# Altium schematic sheet Sheet2.SchDoc
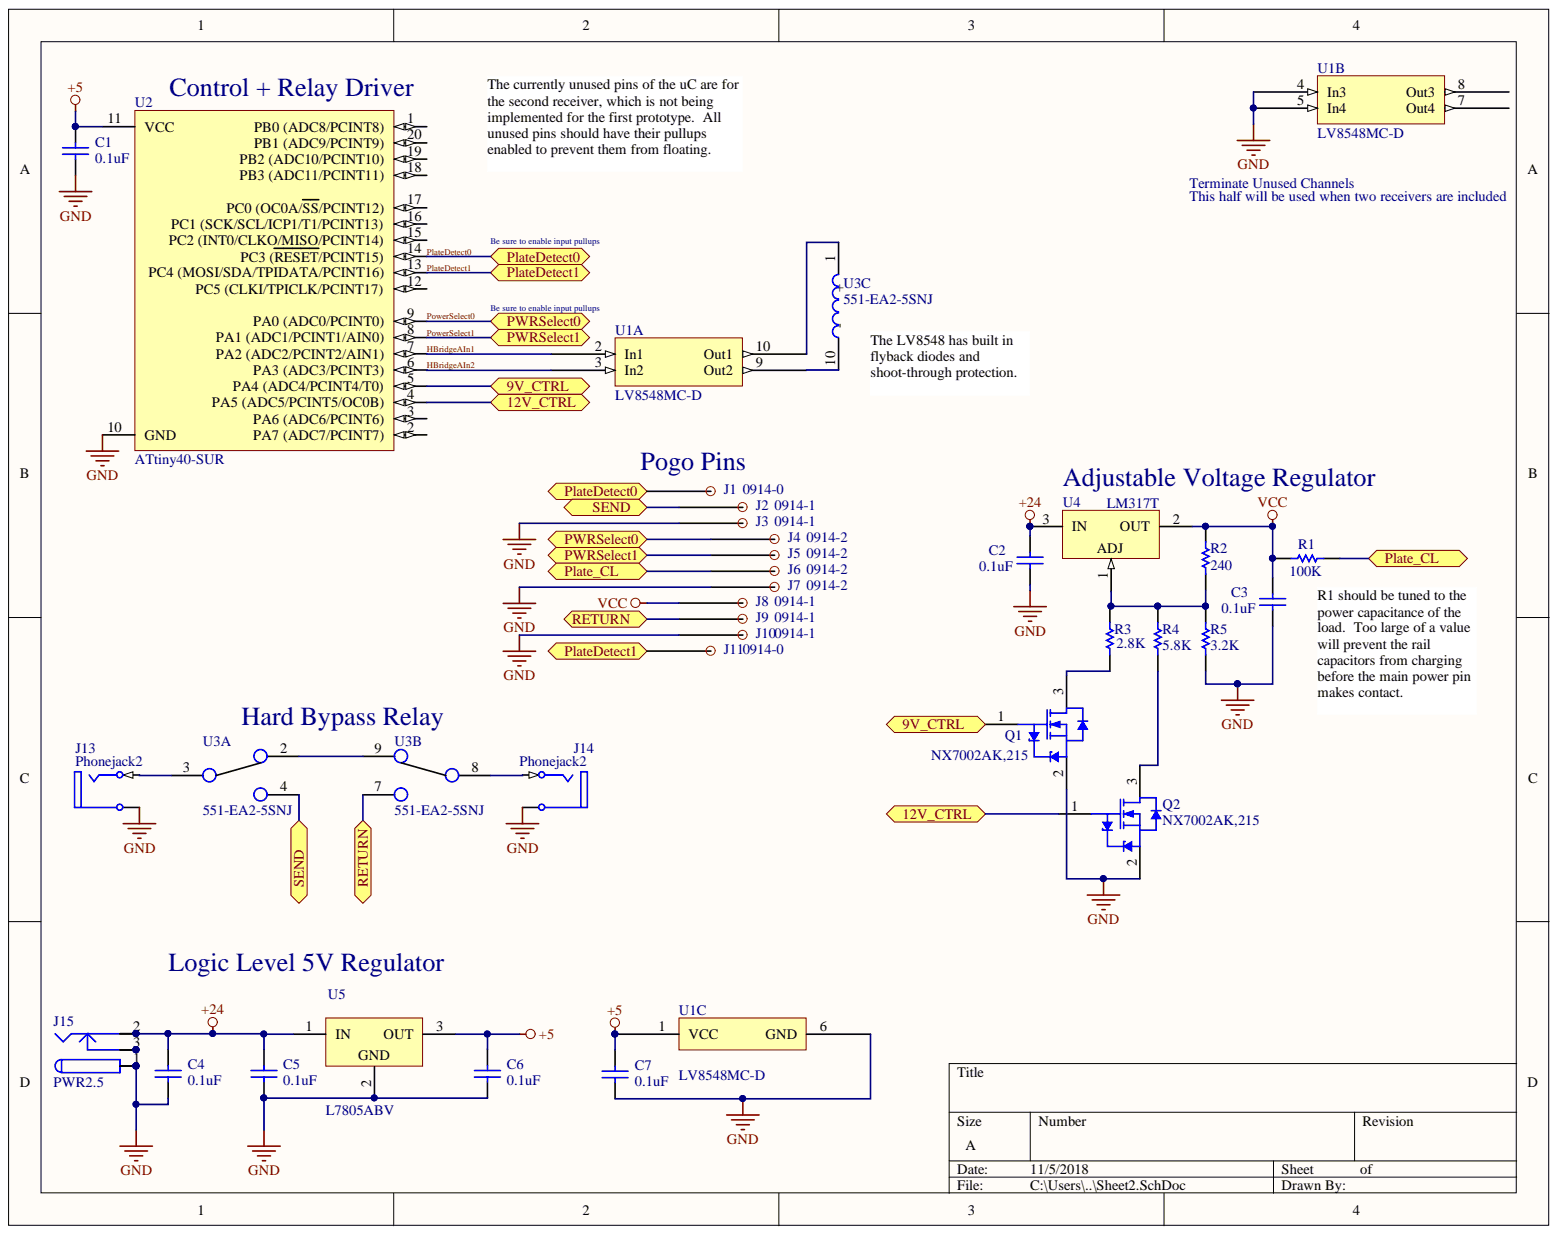
[diagram p0: Sheet2.SchDoc]

## Parts
C1  value 0.1uF  [RAD-0.3]  (hidden comment: Cap)
C2  value 0.1uF  [RAD-0.3]  (hidden comment: Cap)
C3  value 0.1uF  [RAD-0.3]  (hidden comment: Cap)
C4  value 0.1uF  [RAD-0.3]  (hidden comment: Cap)
C5  value 0.1uF  [RAD-0.3]  (hidden comment: Cap)
C6  value 0.1uF  [RAD-0.3]  (hidden comment: Cap)
C7  value 0.1uF  [RAD-0.3]  (hidden comment: Cap)
J1  0914-0-15-20-77-14-11-0  [MillMax0_025]  (value not drawn)
J2  0914-1-15-20-77-14-11-0  [MillMax0_025]  (value not drawn)
J3  0914-1-15-20-77-14-11-0  [MillMax0_025]  (value not drawn)
J4  0914-2-15-20-77-14-11-0  [MillMax0_025]  (value not drawn)
J5  0914-2-15-20-77-14-11-0  [MillMax0_025]  (value not drawn)
J6  0914-2-15-20-77-14-11-0  [MillMax0_025]  (value not drawn)
J7  0914-2-15-20-77-14-11-0  [MillMax0_025]  (value not drawn)
J8  0914-1-15-20-77-14-11-0  [MillMax0_025]  (value not drawn)
J9  0914-1-15-20-77-14-11-0  [MillMax0_025]  (value not drawn)
J10  0914-1-15-20-77-14-11-0  [MillMax0_025]  (value not drawn)
J11  0914-0-15-20-77-14-11-0  [MillMax0_025]  (value not drawn)
J13  Phonejack2  [JACK/6-V2]
J14  Phonejack2  [JACK/6-V2]
J15  PWR2.5  [KLD-0202]
Q1  NX7002AK,215  [NXP-SOT23_N]
Q2  NX7002AK,215  [NXP-SOT23_N]
R1  value 100K  [AXIAL-0.3]  (hidden comment: Res1)
R2  value 240  [AXIAL-0.3]  (hidden comment: Res1)
R3  value 2.8K  [AXIAL-0.3]  (hidden comment: Res1)
R4  value 5.8K  [AXIAL-0.3]  (hidden comment: Res1)
R5  value 3.2K  [AXIAL-0.3]  (hidden comment: Res1)
U1  LV8548MC-D  [SOP10]  (parts A,B,C)
U2  ATtiny40-SUR  [20S2_N]
U3  EA2-5SNJ  Supplier Part Number 1: 551-EA2-5SNJ  (parts A,B,C)
U4  LM317T  [TO-220]
U5  L7805ABV  [TO220]

## Ports
9V_CTRL, 12V_CTRL, Plate_CL, PlateDetect0, PlateDetect1, PWRSelect0, PWRSelect1, RETURN, SEND

## Nets
+5: C1.2 C6.2 C7.2 U1.1(VCC) U2.11(VCC) U5.3
+24: C2.2 C4.2 C5.2 J15.2 J15.2A J15.2B U4.3 U5.1
GND: C1.1 C2.1 C3.1 C4.1 C5.1 C6.1 C7.1 J3.1 J7.1 J10.1 J13.5 J14.5 J15.1 J15.1A J15.1B Q1.2 Q2.2 R5.1 U1.4(In3) U1.5(In4) U1.6(GND) U2.10(GND) U5.2
VCC: C3.2 J8.1 R1.2 R2.2 U4.2
9V_CTRL: Q1.1 U2.5(PA4_(ADC4/PCINT4/T0)) ; port 9V_CTRL
12V_CTRL: Q2.1 U2.4(PA5_(ADC5/PCINT5/OC0B)) ; port 12V_CTRL
HBridgeAIn1: U1.2(In1) U2.7(PA2_(ADC2/PCINT2/AIN1))
HBridgeAIn2: U1.3(In2) U2.6(PA3_(ADC3/PCINT3))
Plate_CL: J6.1 R1.1 ; port Plate_CL
PlateDetect0: J1.1 U2.14(PC3_(RESET/PCINT15)) ; port PlateDetect0
PlateDetect1: J11.1 U2.13(PC4_(MOSI/SDA/TPIDATA/PCINT16)) ; port PlateDetect1
PowerSelect0: J4.1 U2.9(PA0_(ADC0/PCINT0)) ; port PWRSelect0
PowerSelect1: J5.1 U2.8(PA1_(ADC1/PCINT1/AIN0)) ; port PWRSelect1
RETURN: J9.1 U3.7 ; port RETURN
SEND: J2.1 U3.4 ; port SEND
NetJ13_1: J13.1 U3.3
NetJ14_1: J14.1 U3.8
NetJ15_3: J15.3 J15.3A J15.3B
NetQ1_3: Q1.3 R3.1
NetQ2_3: Q2.3 R4.1
NetR2_1: R2.1 R3.2 R4.2 R5.2 U4.1
NetU1_9: U1.9(Out2) U3.10(-)
NetU1_10: U1.10(Out1) U3.1(+)
NetU3_2: U3.2 U3.9

Not connected: U1 7(Out4) 8(Out3); U2 1(PB0_(ADC8/PCINT8)) 2(PA7_(ADC7/PCINT7)) 3(PA6_(ADC6/PCINT6)) 12(PC5_(CLKI/TPICLK/PCINT17)) 15(PC2_(INT0/CLKO/MISO/PCINT14)) 16(PC1_(SCK/SCL/ICP1/T1/PCINT13)) 17(PC0_(OC0A/SS/PCINT12)) 18(PB3_(ADC11/PCINT11)) 19(PB2_(ADC10/PCINT10)) 20(PB1_(ADC9/PCINT9))

## Library data of the parts
C1-C7 = Cap: Capacitor; Component Kind: Standard; LatestRevisionDate: 17-Jul-2002; LatestRevisionNote: Re-released for DXP Platform.; Library Name: Miscellaneous Devices.IntLib; PackageDescription: Radial Cap, Thru-Hole; 2 Leads; 0.3 in Pin Spacing; PackageReference: RAD-0.3; Published: 8-Jun-2000; Signal Integrity: Cap; Simulation: CAP; Publisher: Altium Limited
J1 J11 = 0914-0-15-20-77-14-11-0: CONN PIN SPRING-LOADED PCB GOLD; Manufacturer: Mill-Max; Body Material: Brass; Current Rating: 2A; Number of Contacts: 2; P*: 0914-0; Resistance: 20mR; Component Kind: Standard; ComponentLink1Description: http://www.mouser.com/ds/2/273/025-259718.pdf; ComponentLink1URL: http://www.mouser.com/ds/2/273/025-259718.pdf; ComponentLink2Description: http://www.farnell.com/datasheets/1474874.pdf; ComponentLink2URL: http://www.farnell.com/datasheets/1474874.pdf; ComponentLink3Description: https://www.alliedelec.com/m/d/29d0a7827c1825043739d39c5225e64e.pdf; ComponentLink3URL: https://www.alliedelec.com/m/d/29d0a7827c1825043739d39c5225e64e.pdf; Contact Material: Copper Alloy; Contact Plating: Gold; Lead Free: Lead Free; Length: 0.302inch; Manufacturer Part Number: 0914-0-15-20-77-14-11-0; Material: Copper Alloy; Mount: Panel,Through Hole; Number of Rows: 1; Package Quantity: 500; Packaging: Bulk; Plating: Gold; Pricing 1: 1=0.75, 10=0.706, 25=0.6352, 50=0.5648, 100=0.5412, 250=0.49412, 500=0.4706, 1000=0.38825, 2500=0.35295 (USD); REACH SVHC: Unknown; Stock 1: 29402; Supplier 1: Digi-Key; Termination: Solder; Library Name: NickPhamParts.IntLib
J2 J3 J8-J10 = 0914-1-15-20-77-14-11-0: CONNECTOR; Manufacturer: Mill-Max; J*: 0914-1; Plating: Gold; Component Kind: Standard; Manufacturer Part Number: 0914-1-15-20-77-14-11-0; Material: Brass; Mount: Through Hole; Pricing 1: 1=0.77, 10=0.673, 25=0.6336, 50=0.6072, 100=0.5808, 250=0.528, 500=0.4752, 1000=0.4224, 2500=0.3828 (USD); Stock 1: 17746; Supplier 1: Digi-Key; Library Name: NickPhamParts.IntLib
J4-J7 = 0914-2-15-20-77-14-11-0: CONN PIN PCB .362"; Manufacturer: Mill-Max; Plating: Gold; Component Kind: Standard; Manufacturer Part Number: 0914-2-15-20-77-14-11-0; Material: Brass; Mount: Through Hole; Pricing 1: 1=0.81, 10=0.71, 25=0.6688, 50=0.6408, 100=0.6129, 250=0.5572, 500=0.50148, 1000=0.44576, 2500=0.40397 (USD); Stock 1: 7703; Supplier 1: Digi-Key; Supplier Part Number 1: 0914-2; Library Name: NickPhamParts.IntLib
J13 J14 = Phonejack2: Jack Socket, 1/4" [6.5mm], Thru-Hole, Vertical, 2-Conductor Open Circuit; DrillAndSlotSize: Corresponds to nominal value.; PackageDocument: 2003; PCB_Layout: Complies with manufacturer's recommendation.; Component Kind: Standard; ComponentLink1Description: Manufacturer Link; ComponentLink1URL: http://www.cliffuk.co.uk/; DatasheetDocument: 2003; LatestRevisionDate: 15-Jul-2004; LatestRevisionNote: Component Replaced.; Library Name: Miscellaneous Connectors.IntLib; PackageReference: S1VS_SNS; Signal Integrity: JACK Socket; Publisher: Altium Limited
J15 = PWR2.5: Low Voltage Power Supply Connector; Component Kind: Standard; ComponentLink1Description: Manufacturer Link; ComponentLink1URL: http://domino.kycon.com/internet/Products.html; DatasheetDocument: 09-May-2002; Library Name: Miscellaneous Connectors.IntLib; Published: 17-Dec-2003; Signal Integrity: Connector; Publisher: Altium Limited
Q1 Q2 = NX7002AK,215: Single N-Channel Trench MOSFET, 60 V, -55 to 150 degC, 3-Pin SOT23, Tape and Reel; Manufacturer: NXP Semiconductors; Channel Type: N; Ciss [typ] (pF): 11; Coss [typ] (pF): 3.4; Packing: Tape & Reel; VDS [max] (V): 60; VGSth [typ] (V): 1.6; Code_JEDEC: TO-236AB; Component Kind: Standard; ComponentLink1Description: Manufacturer URL; ComponentLink1URL: http://www.nxp.com/; ComponentLink2Description: Datasheet; ComponentLink2URL: http://www.nxp.com/documents/data_sheet/NX7002AK.pdf; ComponentLink3Description: Package Specification; ComponentLink3URL: http://www.nxp.com/documents/outline_drawing/sot023_po.pdf; DatasheetVersion: Rev. 5; Library Name: NXP Semiconductors Standard MOSFETs.IntLib; Mounting Technology: Surface Mount; PackageDescription: 3-Pin Small Outline Transistor Package, Body 2.9 x 1.3 mm, Pitch 0.95 mm; PackageReference: SOT23; PackageVersion: 06-03-16, 03/2006; PartNumber: NX7002AK,215; Supplier 1: Digi-Key; Supplier 2: Digi-Key; Supplier 3: Digi-Key; Supplier 4: Mouser; Supplier Part Number 1: 568-10510-2-ND; Supplier Part Number 2: 568-10510-1-ND; Supplier Part Number 3: 568-10510-6-ND; Supplier Part Number 4: 771-NX7002AK,215
R1-R5 = Res1: Resistor; Component Kind: Standard; LatestRevisionDate: 17-Jul-2002; LatestRevisionNote: Re-released for DXP Platform.; Library Name: Miscellaneous Devices.IntLib; PackageDescription: Axial Device, Thru-Hole; 2 Leads; 0.3 in Pin Spacing; PackageReference: AXIAL-0.3; Published: 8-Jun-2000; Signal Integrity: Res; Simulation: RESISTOR; Publisher: Altium Limited
U2 = ATtiny40-SUR: 8-bit AVR Microcontroller, 1.8-5.5V, 12MHz, 4KB Flash, 256 Bytes SRAM, 20-pin SOIC, Industrial Grade (-40°C to 85°C), Pb-Free, Reel; Code_IPC: SOIC127P1030-20; Code_JEDEC: MS-013-AC; Component Kind: Standard; ComponentLink1Description: Manufacturer URL; ComponentLink1URL: http://www.atmel.com/; ComponentLink2Description: ATtiny40 Datasheet; ComponentLink2URL: http://www.atmel.com/dyn/resources/prod_documents/doc8263.pdf; ComponentLink3Description: ATtiny40 Summary; ComponentLink3URL: http://www.atmel.com/dyn/resources/prod_documents/8263S.pdf; DatasheetVersion: revA, Aug-2010; Library Name: Atmel Microcontroller tinyAVR.IntLib; PackageDescription: 20-lead, 0.300" Wide Body, Plastic Gull Wing Small Outline Package (SOIC); PackageReference: 20S2; PackageVersion: revB, Nov-2006
U3 = EA2-5SNJ: Low Signal Relays - PCB 5VDC SINGLE LATCH; Manufacturer: NEC; Coil Current: 2A; Coil Type: Latching; Power Consumption: 100mW; Throw Configuration: DPDT; Component Kind: Standard; Manufacturer Part Number: EA2-5SNJ; Package Quantity: 40; Stock 1: 0; Supplier 1: Mouser; Termination: Solder; Library Name: NickPhamParts.IntLib
U4 = LM317T: 1.5A, 1.2V to 37V Adjustable Voltage Regulator, 3-Pin TO-220; Component Kind: Standard; ComponentLink1Description: Manufacturer URL; ComponentLink1URL: http://www.st.com; ComponentLink2Description: Datasheet; ComponentLink2URL: http://www.st.com/internet/com/TECHNICAL_RESOURCES/TECHNICAL_LITERATURE/DATASHEET/CD00000455.pdf; ComponentLink3Description: Package Specification; ComponentLink3URL: http://www.st.com/internet/com/TECHNICAL_RESOURCES/TECHNICAL_LITERATURE/PACKAGE_INFORMATION/CD00004649.pdf; DatasheetVersion: Nov-2011; Library Name: STMicroelectronics Power Management Linear Regulator.IntLib; PackageDescription: TO, Thru-Hole, Vertical, Heatsink Mounted; 3 In-Line Leads; Pitch 2.54 mm; PackageReference: TO-220; PackageVersion: 2011; PartNumber: LM317T
U5 = L7805ABV: Positive Voltage Regulator, 5V, 3-Pin TO-220; Component Kind: Standard; ComponentLink1Description: Manufacturer URL; ComponentLink1URL: http://www.st.com; ComponentLink2Description: Datasheet; ComponentLink2URL: http://www.st.com/internet/com/TECHNICAL_RESOURCES/TECHNICAL_LITERATURE/DATASHEET/CD00000444.pdf; ComponentLink3Description: Package Specification; ComponentLink3URL: http://www.st.com/internet/com/TECHNICAL_RESOURCES/TECHNICAL_LITERATURE/PACKAGE_INFORMATION/CD00004649.pdf; DatasheetVersion: Nov-2011; Library Name: STMicroelectronics Power Management Linear Regulator.IntLib; PackageDescription: TO, Thru-Hole, Vertical, Heatsink Mounted; 3 In-Line Leads; Pitch 2.54 mm; PackageReference: TO220; PackageVersion: ver3.0; PartNumber: L7805ABV
U1 = LV8548MC-D: Component Kind: Standard; Supplier 1: Digi-Key; Supplier Part Number 1: LV8548MC-D; Library Name: NickPhamParts.IntLib
